# Altium schematic sheet [15] - POWER II.SchDoc("[15] - POWER II")
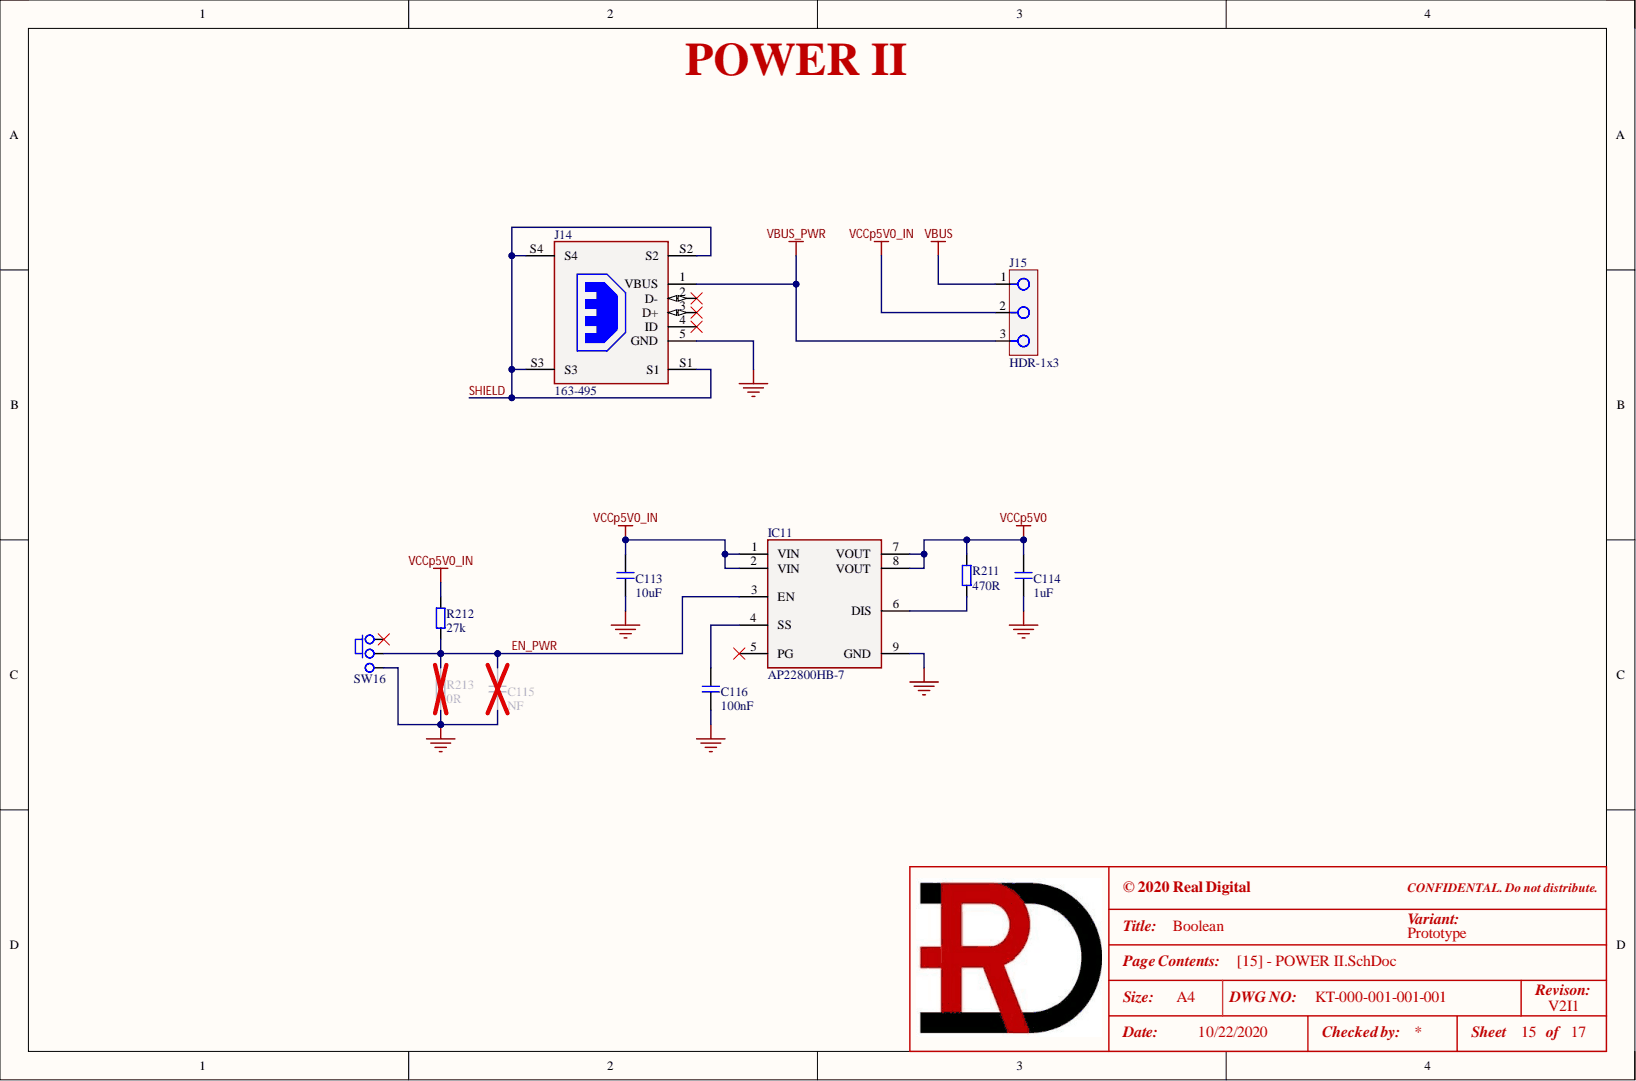
[diagram p0: [15] - POWER II.SchDoc]

## Parts
C113  10uF  [C0805]
C114  1uF  [C0603]
C115  NF  [C0402]
C116  100nF  [C0402]
IC11  AP22800HB-7  [U-DFN2116-8_DIODES]
J14  163-495  [163-495]
J15  HDR-1x3  [HDR1X3]
R211  470R  [R1206]
R212  27k  [R0402]
R213  0R  [R0402]
SW16  Switch  [SW-10SP001]  (value not drawn)

## Nets
GND: C113.1 C114.1 C115.1 C116.1 IC11.9(GND) J14.5(GND) R213.1 SW16.3
VBUS: J15.1
VBUS_PWR: J14.1(VBUS) J15.3
VCCp5V0: C114.2 IC11.7(VOUT) IC11.8(VOUT) R211.2
VCCp5V0_IN: C113.2 IC11.1(VIN) IC11.2(VIN) J15.2 R212.2
EN_PWR: C115.2 IC11.3(EN) R212.1 R213.2 SW16.2
SHIELD: J14.S1 J14.S2 J14.S3 J14.S4
NetC116_2: C116.2 IC11.4(SS)
NetIC11_6: IC11.6(DIS) R211.1

Not connected: IC11 5(PG); J14 2(D-) 3(D+) 4(ID); SW16 1

## Library data of the parts
C113 = GRM21BR71A106KA73L: CAP CER 10uF 10V X7R 0805; Manufacturer 1: Murata Electronics; .Checked_PCB: AC; .Creator: RV; C P/N: 111-0789; LoadStatus: Load; Tolerance: 10%; Category: Capacitors; Component Kind: Standard; Library Name: KT-RealDigital.IntLib; Manufacturer 1 Part Number: GRM21BR71A106KA73L; Temperature Coefficient: X7R; Voltage - Rated: 10V; .Checked_SCH: AC
C114 = GCJ188R71E105KA01D: CAP CER 1uF 25V X7R 0603; Manufacturer 1: Murata Electronics; .Checked_PCB: AC; .Creator: RV; C P/N: 111-0602; LoadStatus: Load; Tolerance: 10%; Category: Capacitors; Component Kind: Standard; Library Name: KT-RealDigital.IntLib; Manufacturer 1 Part Number: GCJ188R71E105KA01D; Temperature Coefficient: X7R; Voltage - Rated: 25V; .Checked_SCH: AC
C115 = CAP0402: Generic Capacitor, 0402; .Creator: RV; LoadStatus: Load; Category: Capacitors; Component Kind: Standard; Library Name: KT-RealDigital.IntLib
C116 = GRM155R71E104KE14J: CAP CER 0.1uF 25V X7R 0402; Manufacturer 1: Murata Electronics; .Checked_PCB: AC; .Creator: RV; C P/N: RD-111-0006; LoadStatus: Load; Tolerance: 10%; Category: Capacitors; Component Kind: Standard; Library Name: KT-RealDigital.IntLib; Manufacturer 1 Part Number: GRM155R71E104KE14J; Temperature Coefficient: X7R; Voltage - Rated: 25V; .Checked_SCH: AC
IC11 = AP22800HB-7: IC PWR SWITCH N-CHAN 1:1 8UDFN; Manufacturer 1: Diodes Incorporated; .Checked_PCB: AC; .Creator: RV; C P/N: RD-126-0004; LoadStatus: Load; Category: IC; Component Kind: Standard; Library Name: KT-RealDigital.IntLib; Manufacturer 1 Part Number: AP22800HB-7; .Checked_SCH: AC
J14 = 163-495: CONN RCPT USB2.0 MICRO B SMD R/A; .Creator: RV; C P/N: 163-0495; LoadStatus: Load; Category: Connectors; Component Kind: Standard; Library Name: KT-RealDigital.IntLib; Manufacturer 1 Part Number: 163-495
J15 = HDR-1x3: Header 2.54mm, 1x3; Manufacturer 1: Centronic Precision Electronic; Manufacturer 1 Part Number: H6-80-03; .Checked_PCB: AC; .Creator: RV; C P/N: 163-0035; LoadStatus: Load; Category: Header; Component Kind: Standard; Library Name: KT-RealDigital.IntLib; .Checked_SCH: AC
R211 = RC1206JR-07470RL: RES SMD 470 OHM 5% 1/4W 1206; Manufacturer 1: Yageo; .Checked_PCB: AC; .Creator: RV; C P/N: 101-0235; LoadStatus: Load; Category: Resistors; Component Kind: Standard; Library Name: KT-RealDigital.IntLib; Manufacturer 1 Part Number: RC1206JR-07470RL; Tolerance: 5%; .Checked_SCH: AC
R212 = RC0402JR-0727KL: RES SMD 27K OHM 5% 1/16W 0402; Manufacturer 1: Yageo; .Checked_PCB: AC; .Creator: RV; C P/N: 101-0802; LoadStatus: Load; Category: Resistors; Component Kind: Standard; Library Name: KT-RealDigital.IntLib; Manufacturer 1 Part Number: RC0402JR-0727KL; Tolerance: 5%; .Checked_SCH: AC
R213 = RC0402FR-070RL: RES SMD 0 OHM JUMPER 1/16W 0402; Manufacturer 1: Yageo; .Checked_PCB: AC; .Creator: RV; C P/N: RD-101-0003; LoadStatus: Load; Category: Resistors; Component Kind: Standard; Library Name: KT-RealDigital.IntLib; Manufacturer 1 Part Number: RC0402FR-070RL; Tolerance: Jumper; .Checked_SCH: AC
SW16 = Slide_Switch: Slide Switch, SPDT, Thru Hole,; Manufacturer 1: Mountain Switch; Manufacturer 1 Part Number: 10SP001; Manufacturer 2 Part Number: BSL-SS12F56-V4; .Checked_PCB: AC; .Creator: RV; C P/N: 153-0001; LoadStatus: Load; Category: Switches; Component Kind: Standard; Library Name: KT-RealDigital.IntLib; .Checked_SCH: AC
